# Altium schematic sheet [04]_Pressure_Sensing.SchDoc([04]_Pressure_Sensing)
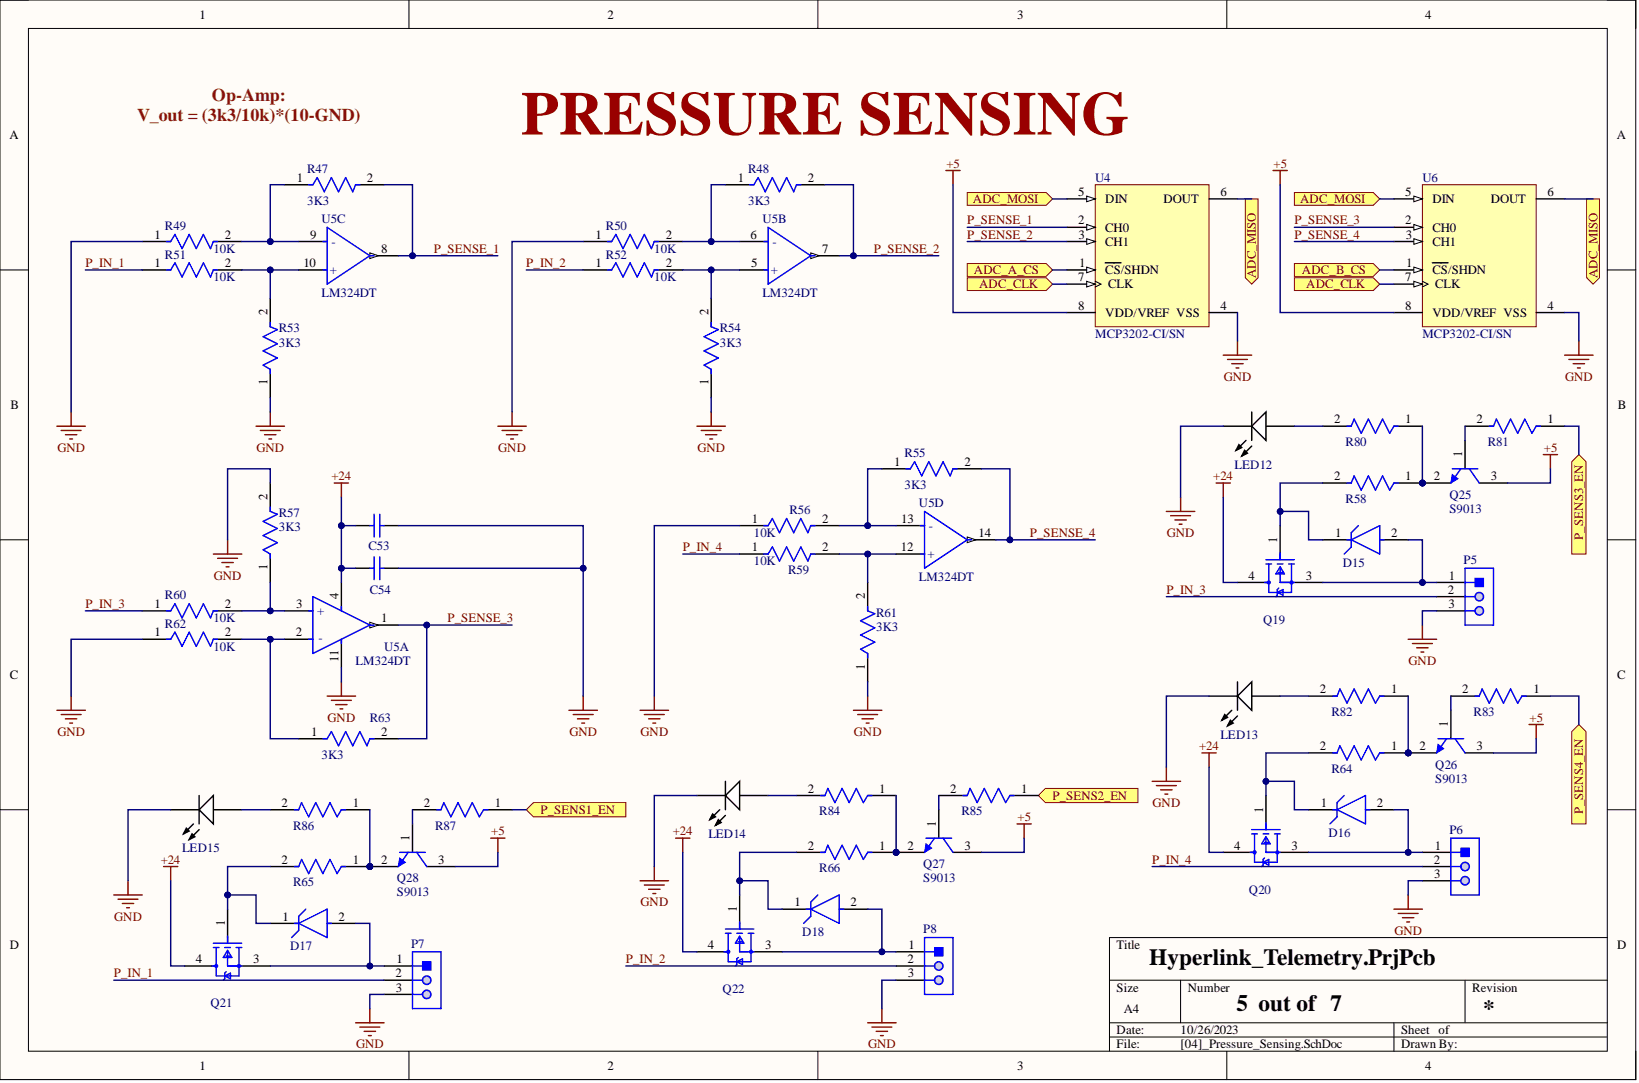
[diagram p0: [04]_Pressure_Sensing.SchDoc]

## Parts
C53  GRM188R71H104KA93D  (value not drawn)
C54  GRM188R71H104KA93D  (value not drawn)
D15  BZT52C15  (value not drawn)
D16  BZT52C15  (value not drawn)
D17  BZT52C15  (value not drawn)
D18  BZT52C15  (value not drawn)
LED12  HSMW-C191  (value not drawn)
LED13  HSMW-C191  (value not drawn)
LED14  HSMW-C191  (value not drawn)
LED15  HSMW-C191  (value not drawn)
P5  691322310003  (value not drawn)
P6  691322310003  (value not drawn)
P7  691322310003  (value not drawn)
P8  691322310003  (value not drawn)
Q19  IRFR3410TRPBF  (value not drawn)
Q20  IRFR3410TRPBF  (value not drawn)
Q21  IRFR3410TRPBF  (value not drawn)
Q22  IRFR3410TRPBF  (value not drawn)
Q25  S9013
Q26  S9013
Q27  S9013
Q28  S9013
R47  3K3
R48  3K3
R49  10K
R50  10K
R51  10K
R52  10K
R53  3K3
R54  3K3
R55  3K3
R56  10K
R57  3K3
R58  0603WAF1200T5E  (value not drawn)
R59  10K
R60  10K
R61  3K3
R62  10K
R63  3K3
R64  0603WAF1200T5E  (value not drawn)
R65  0603WAF1200T5E  (value not drawn)
R66  0603WAF1200T5E  (value not drawn)
R80  CRCW06031K00FKEA  (value not drawn)
R81  0603WAF1200T5E  (value not drawn)
R82  CRCW06031K00FKEA  (value not drawn)
R83  0603WAF1200T5E  (value not drawn)
R84  CRCW06031K00FKEA  (value not drawn)
R85  0603WAF1200T5E  (value not drawn)
R86  CRCW06031K00FKEA  (value not drawn)
R87  0603WAF1200T5E  (value not drawn)
U4  MCP3202-CI/SN
U5  LM324DT  (parts A,B,C,D)
U6  MCP3202-CI/SN

## Ports
ADC_A_CS, ADC_B_CS, ADC_CLK, ADC_MISO, ADC_MOSI, P_SENS1_EN, P_SENS2_EN, P_SENS3_EN, P_SENS4_EN

## Nets
+5: Q25.3 Q26.3 Q27.3 Q28.3 U4.8(VDD/VREF) U6.8(VDD/VREF)
+24: C53.2 C54.2 Q19.4 Q20.4 Q21.4 Q22.4 U5.4
GND: C53.1 C54.1 LED12.2 LED13.2 LED14.2 LED15.2 P5.3 P6.3 P7.3 P8.3 R49.1 R50.1 R53.1 R54.1 R56.1 R57.2 R61.1 R62.1 U4.4(VSS) U5.11 U6.4(VSS)
ADC_A_CS: U4.1(CS/SHDN) ; port ADC_A_CS
ADC_B_CS: U6.1(CS/SHDN) ; port ADC_B_CS
ADC_CLK: U4.7(CLK) U6.7(CLK) ; port ADC_CLK
ADC_MISO: U4.6(DOUT) U6.6(DOUT) ; port ADC_MISO
ADC_MOSI: U4.5(DIN) U6.5(DIN) ; port ADC_MOSI
P_IN_1: P7.2 R51.1
P_IN_2: P8.2 R52.1
P_IN_3: P5.2 R60.1
P_IN_4: P6.2 R59.1
P_SENS1_EN: R87.1 ; port P_SENS1_EN
P_SENS2_EN: R85.1 ; port P_SENS2_EN
P_SENS3_EN: R81.1 ; port P_SENS3_EN
P_SENS4_EN: R83.1 ; port P_SENS4_EN
P_SENSE_1: R47.2 U4.2(CH0) U5.8
P_SENSE_2: R48.2 U4.3(CH1) U5.7
P_SENSE_3: R63.2 U5.1 U6.2(CH0)
P_SENSE_4: R55.2 U5.14 U6.3(CH1)
NetD15_1: D15.1 Q19.1 R58.2
NetD15_2: D15.2 P5.1 Q19.3
NetD16_1: D16.1 Q20.1 R64.2
NetD16_2: D16.2 P6.1 Q20.3
NetD17_1: D17.1 Q21.1 R65.2
NetD17_2: D17.2 P7.1 Q21.3
NetD18_1: D18.1 Q22.1 R66.2
NetD18_2: D18.2 P8.1 Q22.3
NetLED12_1: LED12.1 R80.2
NetLED13_1: LED13.1 R82.2
NetLED14_1: LED14.1 R84.2
NetLED15_1: LED15.1 R86.2
NetQ25_1: Q25.1 R81.2
NetQ25_2: Q25.2 R58.1 R80.1
NetQ26_1: Q26.1 R83.2
NetQ26_2: Q26.2 R64.1 R82.1
NetQ27_1: Q27.1 R85.2
NetQ27_2: Q27.2 R66.1 R84.1
NetQ28_1: Q28.1 R87.2
NetQ28_2: Q28.2 R65.1 R86.1
NetR47_1: R47.1 R49.2 U5.9(-)
NetR48_1: R48.1 R50.2 U5.6(-)
NetR51_2: R51.2 R53.2 U5.10(+)
NetR52_2: R52.2 R54.2 U5.5(+)
NetR55_1: R55.1 R56.2 U5.13(-)
NetR57_1: R57.1 R60.2 U5.3(+)
NetR59_2: R59.2 R61.2 U5.12(+)
NetR62_2: R62.2 R63.1 U5.2(-)

## Library data of the parts
C53 C54 = CMP-2100-03643-1: Chip Capacitor, 0.1 uF, +/- 10%, 50 V, -55 to 125 degC, 0603 (1608 Metric), RoHS, Tape and Reel; Manufacturer: Murata; Capacitance: 100nF; Dielectric: X7R; Dielectric Material: Ceramic; Insulation Resistance: 10GR; Voltage: 50V; Case Code (Imperial): 0603; Case Code (Metric): 1608; Case/Package: 0603; Component Kind: Standard; Contact Plating: Tin; Datasheet URL: http://psearch.en.murata.com/capacitor/product/GRM188R71H104KA93%23.pdf; Datasheet Version: 42675.0; Depth: 0.8mm; Height: 0.8mm; JLC: C14663; Lead Free: LeadFree; Length: 1.6mm; Library Name: Altium Content Vault; Manufacturer URL: http://www.murata.com/; Material: Ceramic; Max Operating Temperature: 125degC; Min Operating Temperature: -55degC; Mount: SurfaceMount; Number of Pins: 2; Package Description: 2-Pin Surface Mount Device, Body 1.6 x 0.8 mm; Package Quantity: 1; Package Reference: 0603; Packaging: TapeandReel; Radiation Hardening: No; REACH SVHC: NoSVHC; RoHSCompliant: true; Termination: SMD/SMT; Thickness: 0.035inch; Tolerance: 10%; Voltage Rating: 50V; Voltage Rating (DC): 50V; Width: 0.8mm
D15-D18 = CMP-2000-05976-2: DIODE ZENER 15V 500MW SOD123; Manufacturer: Diodes Incorporated; Manufacturer Part Number: BZT52C5V1-7-F; Automotive Grade: NA; Forward Current: 10mA; Max Junction Temp: +150°C; Max Reverse Leakage Current: 2uA; Max Zener Voltage: 5.4V; Nom Zener Voltage: 5.1V; Power Dissipation: 370mW; Standoff Height: 0mm; Zener Impedance: 60Ω; Automotive: No; Category: Diode; Component Kind: Standard; ComponentLink2Description: Datasheet; ComponentLink2URL: https://www.diodes.com/assets/Datasheets/ds18004.pdf; Device Class L1: Discrete Semiconductors; Device Class L2: Diodes; Device Class L3: Zener Diodes; Digikey Description: DIODE ZENER 5.1V 500MW SOD123; Footprint Url: https://www.diodes.com/assets/Package-Files/SOD123.pdf; Height: 1.45mm; JLC: C173427; Lead Free: Yes; Library Name: Altium Content Vault; Mouser Description: Zener Diodes 5.1V 500mW; Octopart: https://octopart.com/bzt52c5v1-7-f-diodes+inc.-326718; Package: SOD123; RoHS: TRUE; Temperature: -65°C to +150°C; Temperature Range High: +150°C; Temperature Range Low: -65°C
LED12-LED15 = CMP-1744-00001-1: LED Uni-Color GREEN, 78 mW, 20 mA, -40 to 85 degC, 2-Pin SMD, RoHS, Tape and Reel; Current Rating: 300 mA; Component Kind: Standard; ComponentLink1Description: Manufacturer URL; ComponentLink1URL: http://www.avagotech.com/; ComponentLink2Description: Datasheet; ComponentLink2URL: http://docs.avagotech.com/docs/AV02-0186EN; Contact Finish: Silver; Contact Material: Copper; Contact Plating: Silver; DatasheetVersion: May-10; Height: 12 mm; JLC: C72041; Length: 16 mm; Library Name: Altium Content Vault; Max Operating Temperature: 85 degC; Min Operating Temperature: -20 degC; Mount: Through Hole; Package Quantity: 560; PackageDescription: 2-Pin Surface Mount Device, Body 1.6 x 0.8 mm; PackageReference: HSMW-C191; Packaging: Bulk; Radiation Hardening: No; REACH SVHC: Unknown; RoHSCompliant: true; Width: 12 mm
P5-P8 = CMP-1502-01887-1: Serie 322 - 3.81mm Horizontal PCB Header WR-TBL, 3 pin; Manufacturer: Wurth Elektronik; Gender: Male; Pin Number: 3; Component Kind: Standard; Datasheet URL: http://katalog.we-online.de/em/datasheet/6913223100xx.pdf; JLC: C8406; Library Name: Altium Content Vault; Manufacturer URL: http://www.we-online.de; Mounting Technology: THT; Operating Temperature: -40to105degC; Package Reference: 691322310003; Packaging: Box; Part Number: 691322310003; Pitch: 3.81mm; Publisher: WurthElektronik
Q19-Q22 = CMP-32901-000329-1: MOSFET N-CH 100V 31A DPAK; Drain to Source Resistance: 39mR; Gate to Source Voltage (Vgs): 20V; Input Capacitance: 1.69nF; Max Power Dissipation: 3W; Power Dissipation: 110W; Threshold Voltage: 4V; Turn-Off Delay Time: 40ns; Turn-On Delay Time: 12ns; Case/Package: TO-252-3; Component Kind: Standard; Continuous Drain Current (ID): 31A; Drain to Source Breakdown Voltage: 100V; Drain to Source Voltage (Vdss): 100V; Halogen Free: Halogen Free; Height: 0.094inch; JLC: C2583; Lead Free: Contains Lead; Length: 0.264inch; Library Name: Altium Content Vault; Max Dual Supply Voltage: 100V; Max Operating Temperature: 175°C; Min Operating Temperature: -55°C; Mount: Surface Mount; Number of Pins: 3; Packaging: Tape and Reel; Rds On Max: 39mR; REACH SVHC: No SVHC; RoHS Compliant: Yes; Width: 0.245inch
Q25-Q28 = CMP-1048-00662-1: NPN Silicon, 3-Pin SOT-23, Pb-Free, Tape and Reel; Manufacturer: On Semiconductor; PartNumber: MMBTA42LT1G; fT Min (MHz): 50; IC Continuous (A): 0.5; Component Kind: Standard; ComponentLink1Description: Manufacturer URL; ComponentLink1URL: http://www.onsemi.com/; ComponentLink2Description: Datasheet; ComponentLink2URL: http://www.onsemi.cn/pub_link/Collateral/MMBTA42LT1-D.PDF; ComponentLink3Description: Package Specification; ComponentLink3URL: http://www.onsemi.cn/pub_link/Collateral/318-08.PDF; DatasheetVersion: Rev. 12; JLC: C6749; Library Name: Altium Content Vault; Mounting Technology: Surface Mount; PackageDescription: 3-Pin Small Outline Transistor, Body 2.92 x 1.3 mm, Pitch 0.95 mm; PackageReference: SOT-23-3-318-08; PackageVersion: Rev. AP, 11/2009; Packing: Tape and Reel; Polarity: NPN; RoHS: TRUE
R47 R48 R53-R55 R57 R61 R63 = CMP-2001-03876-2: RES Thick Film, 3.3kΩ, 1%, 0.125W, 100ppm/°C, 0805; Max Power Dissipation: 125mW; Resistance: 3.3kR; Voltage Rating: 150V; Case Code (Imperial): 0805; Case Code (Metric): 2012; Case/Package: 0805; Component Kind: Standard; Composition: Thick Film; Contact Plating: Tin; Depth: 1.25mm; Features: Automotive AEC-Q200; Height: 0.45mm; JLC: C26010; Lead Free: Lead Free; Length: 2mm; Library Name: Altium Content Vault; Max Operating Temperature: 155°C; Military Standard: Not; Min Operating Temperature: -55°C; Mount: Surface Mount; Number of Terminations: 2; Package Quantity: 10; Packaging: Tape and Reel; Power Rating: 125mW; Radiation Hardening: No; Temperature Coefficient: 100ppm/°C; Termination: SMD/SMT; Tolerance: 1%; Voltage Rating (DC): 150V; Weight: 0.000194oz; Width: 1.25mm
R49-R52 R56 R59 R60 R62 = CMP-2001-04908-2: RES Thick Film, 10kΩ, 1%, 0.125W, 100ppm/°C, 0805; Power Rating: 125mW; Resistance: 10kR; Voltage Rating: 150V; Case Code (Imperial): 0805; Case Code (Metric): 2012; Case/Package: 0805; Component Kind: Standard; Composition: Thick Film; Contact Plating: Tin; Depth: 1.25mm; Height: 0.45mm; JLC: C17414; Lead Free: Lead Free; Length: 2mm; Library Name: Altium Content Vault; Max Operating Temperature: 155°C; Military Standard: Not; Min Operating Temperature: -55°C; Package Quantity: 5000; Packaging: Tape and Reel; Radiation Hardening: No; Temperature Coefficient: 100ppm/°C; Termination: SMD/SMT; Tolerance: 1%; Voltage Rating (DC): 150V; Weight: 0.000194oz; Width: 1.25mm
R58 R64-R66 R81 R83 R85 R87 = CMP-1012-00052-3: RES Thick Film, 120Ω, 5%, 0.1W, 200ppm/°C, 0603; Max Power Dissipation: 100mW; Resistance: 120R; Voltage Rating: 75V; Case Code (Imperial): 0603; Case Code (Metric): 1608; Case/Package: 0603; Component Kind: Standard; Composition: Thick Film; Contact Plating: Tin; Depth: 0.85mm; Features: Automotive AEC-Q200; Height: 0.02inch; JLC: C22787; Lead Free: Lead Free; Length: 1.55mm; Library Name: Altium Content Vault; Max Operating Temperature: 155°C; Military Standard: Not; Min Operating Temperature: -55°C; Number of Pins: 2; Number of Terminations: 2; Package Quantity: 1; Packaging: Tape and Reel; Power Rating: 100mW; Radiation Hardening: No; Temperature Coefficient: 200ppm/°C; Termination: SMD/SMT; Tolerance: 5%; Voltage Rating (DC): 75V; Weight: 7.1E-05oz; Width: 0.85mm
R80 R82 R84 R86 = CMP-1666-00003-5: RES Thick Film, 1kΩ, 1%, 0.1W, 100ppm/°C, 0603; Max Power Dissipation: 100mW; Resistance: 1kR; Voltage Rating: 75V; Case Code (Imperial): 0603; Case Code (Metric): 1608; Case/Package: 0603; Component Kind: Standard; Composition: Thick Film; Contact Plating: Tin; Depth: 0.85mm; Features: Automotive AEC-Q200; Height: 0.45mm; JLC: C21190; Lead Free: Lead Free; Length: 1.55mm; Library Name: Altium Content Vault; Max Operating Temperature: 155°C; Military Standard: Not; Min Operating Temperature: -55°C; Mount: Surface Mount; Number of Pins: 2; Number of Terminations: 2; Package Quantity: 10; Packaging: Tape and Reel; Power Rating: 100mW; Radiation Hardening: No; Temperature Coefficient: 100ppm/°C; Termination: SMD/SMT; Tolerance: 1%; Voltage Rating (DC): 75V; Weight: 7.1E-05oz; Width: 0.033inch
U4 U6 = CMP-0188-00474-2: 2.7V Dual Channel 12-Bit A/D Converter with SPI Serial Interface, 8-Pin SOIC 150mil, C Performance Grade, Industrial Temperature; Code_IPC: SOIC127P600-8; Code_JEDEC: MS-012; Component Kind: Standard; ComponentLink1Description: Manufacturer URL; ComponentLink1URL: http://www.microchip.com/; ComponentLink2Description: Datasheet; ComponentLink2URL: http://ww1.microchip.com/downloads/en/DeviceDoc/21034F.pdf; ComponentLink3Description: Package Specification; ComponentLink3URL: http://www.microchip.com/stellent/groups/techpub_sg/documents/packagingspec/en012702.pdf; DatasheetVersion: revF, Nov-2011; JLC: C56997; Library Name: Altium Content Vault; PackageDescription: 8-Lead Plastic Small Outline (SN) - Narrow, 3.90mm Body [SOIC]; PackageReference: SOIC-SN8; PackageVersion: revBB, Aug-2009; RevisionNote: URLs added. DatasheetVersion updated.
U5 = CMP-12131-000005-1: IC OPAMP GP 4 CIRCUIT 14SO; Manufacturer: STMicroelectronics; Bandwidth: 1.3MHz; Input Bias Current: 0.02uA; Input Offset Voltage: 2mV; Max Dual Supply Voltage: 15V; Max Junction Temp: +150°C; Max Supply Voltage: 30V; Min Dual Supply Voltage: 1.5V; Min Supply Voltage: 3V; Nominal Supply Current: 0.7-1.5mA; Number Of Channels: 4; Output Current Per Channel: 20-50mA; Standoff Height: 0.1mm; Voltage Gain: 100dB; Automotive: No; Category: IC; Component Kind: Standard; ComponentLink2Description: Datasheet; ComponentLink2URL: https://www.st.com/content/ccc/resource/technical/document/datasheet/bd/fc/46/43/26/8f/40/7f/CD00001046.pdf/files/CD00001046.pdf/jcr:content/translations/en.CD00001046.pdf; Device Class L1: Integrated Circuits (ICs); Device Class L2: Linear ICs; Device Class L3: Amplifiers - Op Amps; Digikey Description: IC OPAMP GP 4 CIRCUIT 14SO; Footprint Url: https://www.st.com/content/ccc/resource/technical/document/datasheet/group3/10/8a/e6/12/f8/e8/49/ce/DM00366438/files/DM00366438.pdf/jcr:content/translations/en.DM00366438.pdf; Gain Bandwidth: 1.3MHz; Height: 1.75mm; Lead Free: Yes; Library Name: Altium Content Vault; Manufacturer Part Number: LM324DT; Mouser Description: LM324 Series 32 V 1.3 MHz Low Power Quad Operational Amplifier - SOIC-14; Octopart: https://octopart.com/lm324dt-stmicroelectronics-39579146; Package: SO-14; RoHS: TRUE; Temperature: 0°C to +70°C; Temperature Range High: +70°C; Temperature Range Low: 0°C
